# Altium schematic sheet Mcu.SchDoc
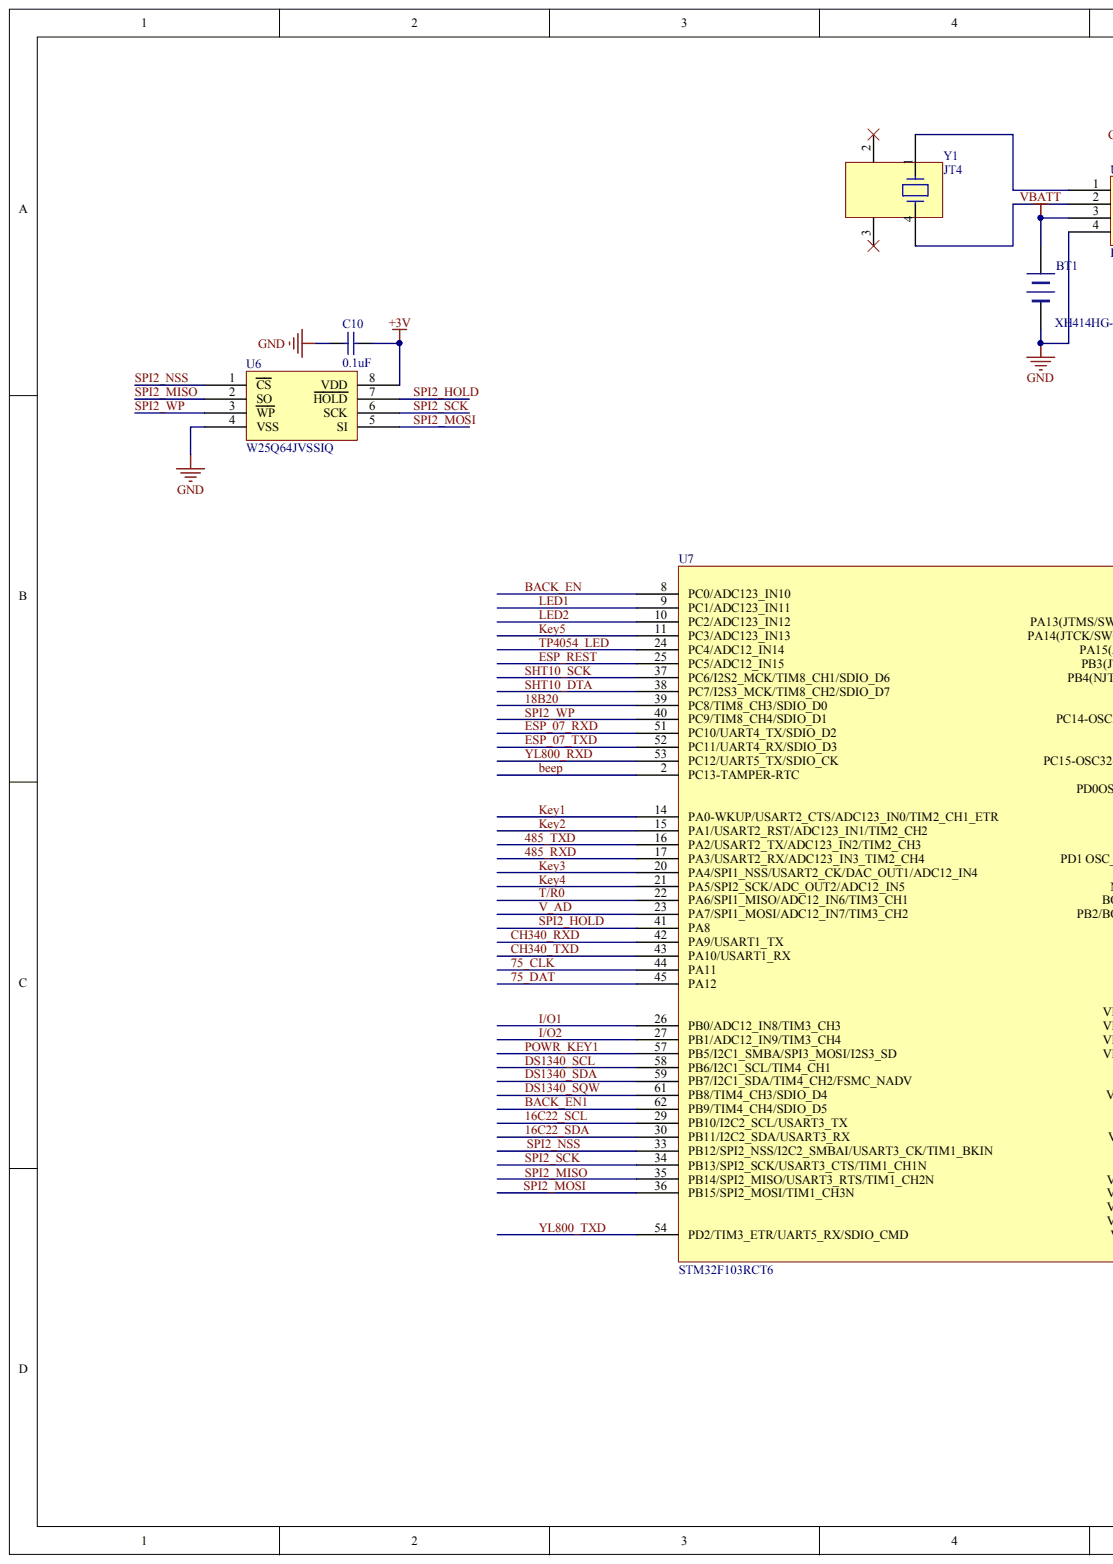
[diagram p0: Mcu.SchDoc, left]
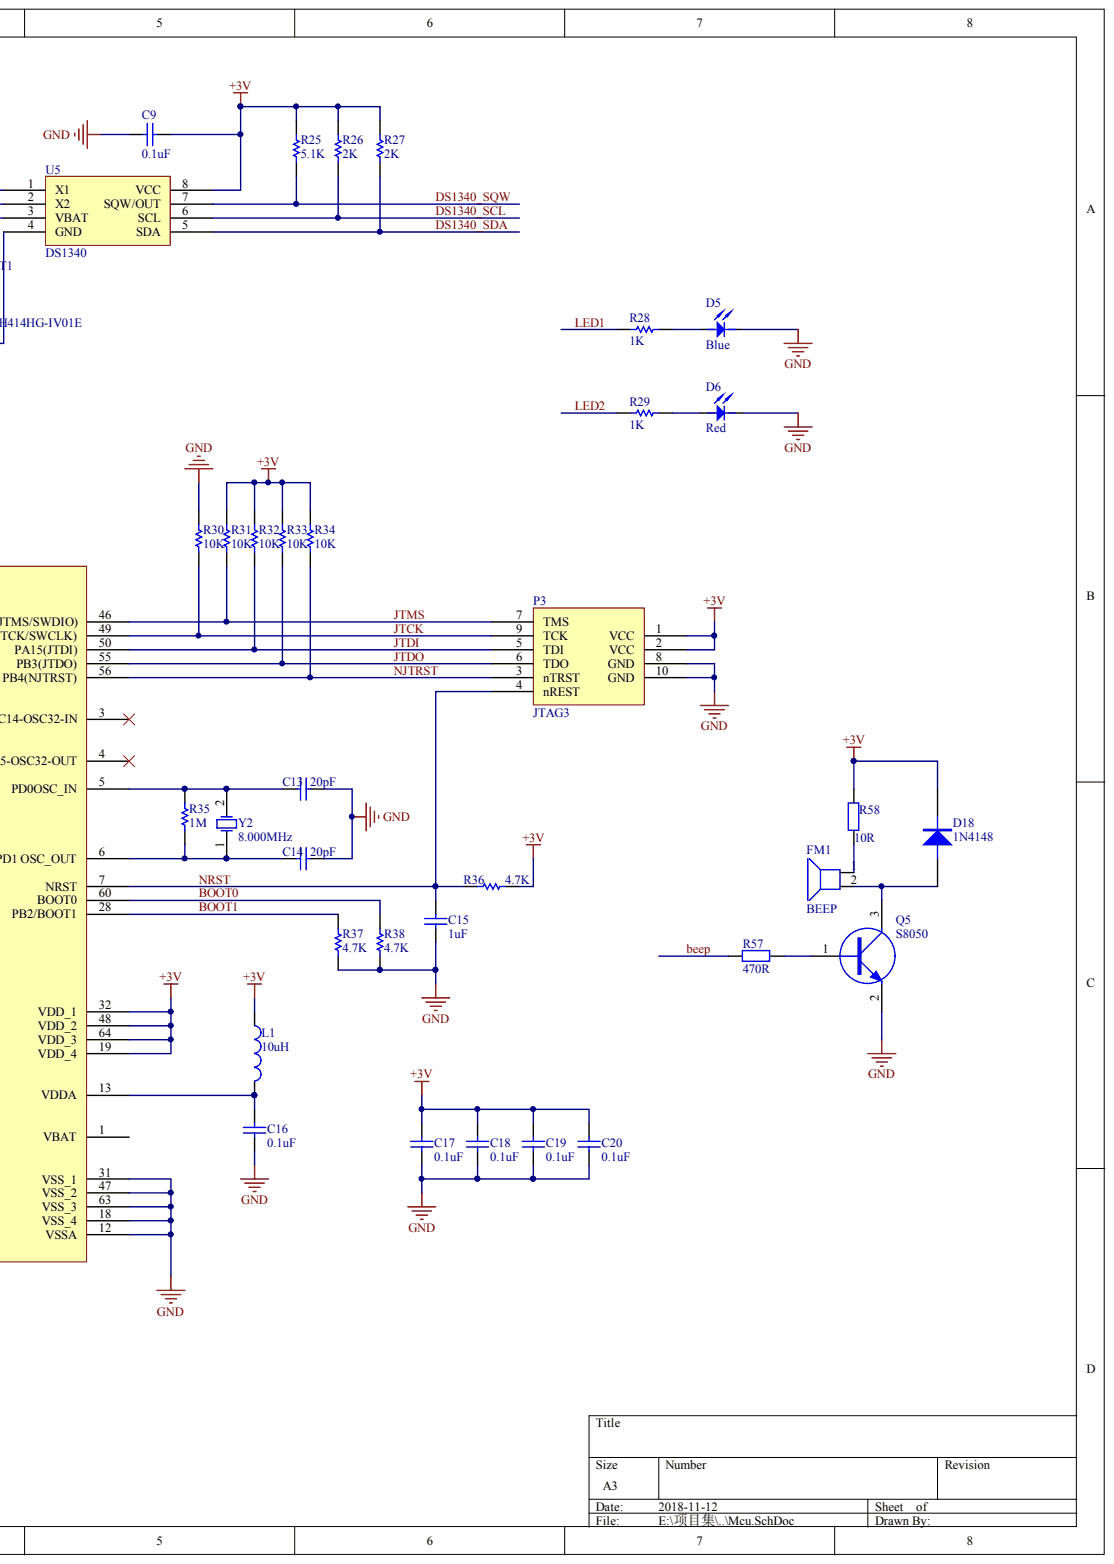
[diagram p1: Mcu.SchDoc, right]

## Parts
BT1  XH414HG-IV01E  [XH414HG]
C9  0.1uF  [0603C]
C10  0.1uF  [0603C]
C13  20pF  [0603C]
C14  20pF  [0603C]
C15  1uF  [0603C]
C16  0.1uF  [0603C]
C17  0.1uF  [0603C]
C18  0.1uF  [0603C]
C19  0.1uF  [0603C]
C20  0.1uF  [0603C]
D5  Blue  [LED-2*3*4]
D6  Red  [LED-2*3*4]
D18  1N4148  [SOD-323]
FM1  BEEP  [FMQ - MLT-5030]
L1  10uH  [0603L]
P3  JTAG3  [IDC10]
Q5  S8050  [SOT-23]
R25  5.1K  [0603R]
R26  2K  [0603R]
R27  2K  [0603R]
R28  1K  [0603R]
R29  1K  [0603R]
R30  10K  [0603R]
R31  10K  [0603R]
R32  10K  [0603R]
R33  10K  [0603R]
R34  10K  [0603R]
R35  1M  [0603R]
R36  4.7K  [0603R]
R37  4.7K  [0603R]
R38  4.7K  [0603R]
R57  470R  [0603R]
R58  10R  [0603R]
U5  DS1340  [SO-8]
U6  W25Q64JVSSIQ  [SOP-8_208mil]
U7  STM32F103RCT6  [LQFP64-0.5]
Y1  JT4  [MC306]
Y2  8.000MHz  [SMD2_50X32]

## Nets
+3V: C9.1 C10.1 C17.2 C18.2 C19.2 C20.2 D18.2 L1.1 P3.1(VCC) P3.2(VCC) R25.2 R26.2 R27.2 R31.2 R32.2 R33.2 R34.2 R36.1 R58.1 U5.8(VCC) U6.8(VDD) U7.19(VDD_4) U7.32(VDD_1) U7.48(VDD_2) U7.64(VDD_3)
GND: BT1.2 C9.2 C10.2 C13.2 C14.2 C15.1 C16.1 C17.1 C18.1 C19.1 C20.1 D5.K D6.K P3.8(GND) P3.10(GND) Q5.2 R30.2 R37.2 R38.2 U5.4(GND) U6.4(VSS) U7.12(VSSA) U7.18(VSS_4) U7.31(VSS_1) U7.47(VSS_2) U7.63(VSS_3)
VBATT: BT1.1 U5.3(VBAT)
16C22_SCL: U7.29(PB10/I2C2_SCL/USART3_TX)
16C22_SDA: U7.30(PB11/I2C2_SDA/USART3_RX)
18B20: U7.39(PC8/TIM8_CH3/SDIO_D0)
75_CLK: U7.44(PA11)
75_DAT: U7.45(PA12)
485_RXD: U7.17(PA3/USART2_RX/ADC123_IN3_TIM2_CH4)
485_TXD: U7.16(PA2/USART2_TX/ADC123_IN2/TIM2_CH3)
BACK_EN: U7.8(PC0/ADC123_IN10)
BACK_EN1: U7.62(PB9/TIM4_CH4/SDIO_D5)
BEEP: R57.2 U7.2(PC13-TAMPER-RTC)
BOOT0: R38.1 U7.60(BOOT0)
BOOT1: R37.1 U7.28(PB2/BOOT1)
CH340_RXD: U7.42(PA9/USART1_TX)
CH340_TXD: U7.43(PA10/USART1_RX)
DS1340_SCL: R26.1 U5.6(SCL) U7.58(PB6/I2C1_SCL/TIM4_CH1)
DS1340_SDA: R27.1 U5.5(SDA) U7.59(PB7/I2C1_SDA/TIM4_CH2/FSMC_NADV)
DS1340_SQW: R25.1 U5.7(SQW/OUT) U7.61(PB8/TIM4_CH3/SDIO_D4)
ESP_07_RXD: U7.51(PC10/UART4_TX/SDIO_D2)
ESP_07_TXD: U7.52(PC11/UART4_RX/SDIO_D3)
ESP_REST: U7.25(PC5/ADC12_IN15)
I/O1: U7.26(PB0/ADC12_IN8/TIM3_CH3)
I/O2: U7.27(PB1/ADC12_IN9/TIM3_CH4)
JTCK: P3.9(TCK) R30.1 U7.49(PA14(JTCK/SWCLK))
JTDI: P3.5(TDI) R32.1 U7.50(PA15(JTDI))
JTDO: P3.6(TDO) R33.1 U7.55(PB3(JTDO))
JTMS: P3.7(TMS) R31.1 U7.46(PA13(JTMS/SWDIO))
KEY1: U7.14(PA0-WKUP/USART2_CTS/ADC123_IN0/TIM2_CH1_ETR)
KEY2: U7.15(PA1/USART2_RST/ADC123_IN1/TIM2_CH2)
KEY3: U7.20(PA4/SPI1_NSS/USART2_CK/DAC_OUT1/ADC12_IN4)
KEY4: U7.21(PA5/SPI2_SCK/ADC_OUT2/ADC12_IN5)
KEY5: U7.11(PC3/ADC123_IN13)
LED1: R28.2 U7.9(PC1/ADC123_IN11)
LED2: R29.2 U7.10(PC2/ADC123_IN12)
NJTRST: P3.3(nTRST) R34.1 U7.56(PB4(NJTRST))
NRST: C15.2 P3.4(nREST) R36.2 U7.7(NRST)
POWR_KEY1: U7.57(PB5/I2C1_SMBA/SPI3_MOSI/I2S3_SD)
SHT10_DTA: U7.38(PC7/I2S3_MCK/TIM8_CH2/SDIO_D7)
SHT10_SCK: U7.37(PC6/I2S2_MCK/TIM8_CH1/SDIO_D6)
SPI2_HOLD: U6.7(HOLD) U7.41(PA8)
SPI2_MISO: U6.2(SO) U7.35(PB14/SPI2_MISO/USART3_RTS/TIM1_CH2N)
SPI2_MOSI: U6.5(SI) U7.36(PB15/SPI2_MOSI/TIM1_CH3N)
SPI2_NSS: U6.1(CS) U7.33(PB12/SPI2_NSS/I2C2_SMBAI/USART3_CK/TIM1_BKIN)
SPI2_SCK: U6.6(SCK) U7.34(PB13/SPI2_SCK/USART3_CTS/TIM1_CH1N)
SPI2_WP: U6.3(WP) U7.40(PC9/TIM8_CH4/SDIO_D1)
T/R0: U7.22(PA6/SPI1_MISO/ADC12_IN6/TIM3_CH1)
TP4054_LED: U7.24(PC4/ADC12_IN14)
V_AD: U7.23(PA7/SPI1_MOSI/ADC12_IN7/TIM3_CH2)
YL800_RXD: U7.53(PC12/UART5_TX/SDIO_CK)
YL800_TXD: U7.54(PD2/TIM3_ETR/UART5_RX/SDIO_CMD)
NetC13_1: C13.1 R35.2 U7.5(PD0OSC_IN) Y2.2
NetC14_1: C14.1 R35.1 U7.6(PD1_OSC_OUT) Y2.1
NetC16_2: C16.2 L1.2 U7.13(VDDA)
NetD5_A: D5.A R28.1
NetD6_A: D6.A R29.1
NetD18_1: D18.1 FM1.2 Q5.3
NetFM1_1: FM1.1 R58.2
NetQ5_1: Q5.1 R57.1
NetU5_1: U5.1(X1) Y1.1
NetU5_2: U5.2(X2) Y1.4

Not connected: U7 1(VBAT) 3(PC14-OSC32-IN) 4(PC15-OSC32-OUT); Y1 2 3

## Library data of the parts
BT1 = BATTERXH1.25: Component Kind: Standard; Library Name: My Devices.SCHLIB
C9 C10 C13-C20 = Cap: Capacitor; Value: 100pF; Component Kind: Standard; LatestRevisionDate: 17-Jul-2002; LatestRevisionNote: Re-released for DXP Platform.; Library Name: Miscellaneous Devices.IntLib; PackageReference: RAD-0.3; Published: 8-Jun-2000; Simulation: CAP; Publisher: Altium Limited
D5 D6 = FD: Component Kind: Standard; Library Name: My Devices.SCHLIB
D18 = DIODE: Component Kind: Standard; Library Name: My Devices.SCHLIB
FM1 = PRO1045.TMP_UNNAMEDE_109: Component Kind: Standard; Library Name: My Devices.SCHLIB
L1 = Inductor: Code_IEC: 1005; Value: 10mH; Code_JEITA: 0402; Component Kind: Standard; Description: Inductor; LatestRevisionDate: 17-Jul-2002; LatestRevisionNote: Re-released for DXP Platform.; Library Name: Miscellaneous Devices.IntLib; PackageReference: 0402-A; Published: 8-Jun-2000; Simulation: INDUCTOR; Publisher: Altium Limited
Q5 = NPN: Component Kind: Standard; Library Name: My Devices.SCHLIB
R25-R27 R30-R38 = Res1: Resistor; Value: 1K; Component Kind: Standard; LatestRevisionDate: 17-Jul-2002; LatestRevisionNote: Re-released for DXP Platform.; Library Name: Miscellaneous Devices.IntLib; PackageReference: AXIAL-0.3; Published: 8-Jun-2000; Simulation: RESISTOR; Publisher: Altium Limited
R28 R29 = Res1: Resistor; Component Kind: Standard; LatestRevisionDate: 17-Jul-2002; LatestRevisionNote: Re-released for DXP Platform.; Library Name: Miscellaneous Devices.IntLib; PackageReference: AXIAL-0.3; Published: 8-Jun-2000; Simulation: RESISTOR; Value: 1K; Publisher: Altium Limited
R57 R58 = RES2: Component Kind: Standard; Library Name: My Devices.SCHLIB
U6 = W25X40BVSNIG: Component Kind: Standard; Library Name: My Devices.SCHLIB
Y2 = XTAL: Crystal Oscillator; Component Kind: Standard; LatestRevisionDate: 17-Jul-2002; LatestRevisionNote: Re-released for DXP Platform.; Library Name: Miscellaneous Devices.IntLib; PackageReference: R38; Published: 8-Jun-2000; Simulation: XTAL; Publisher: Altium Limited
P3 = JTAG3: Component Kind: Standard; Library Name: My Devices.SCHLIB
U5 = DS1340: Component Kind: Standard; Library Name: My Devices.SCHLIB
U7 = STM32F103RCT6: Component Kind: Standard; Library Name: My Devices.SCHLIB
Y1 = JT4: Component Kind: Standard; Library Name: My Devices.SCHLIB
